# Altium schematic sheet tsal.SchDoc
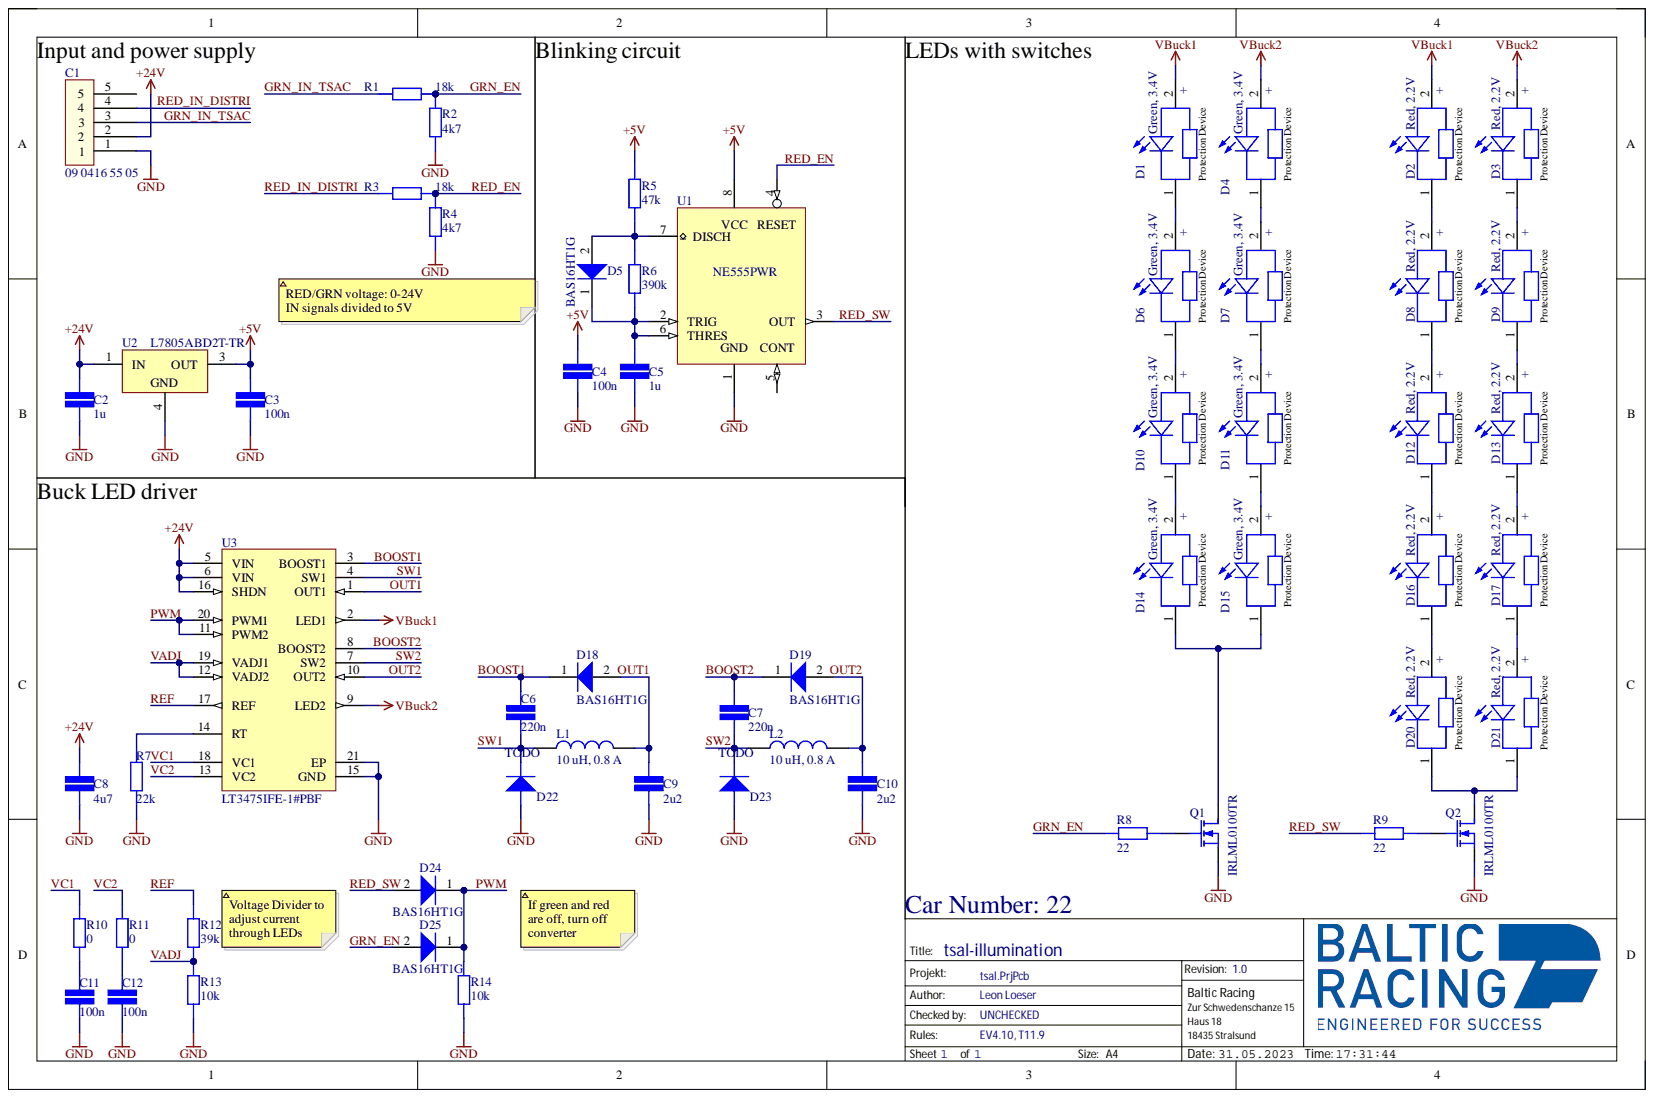
[diagram p0: tsal.SchDoc]

## Parts
C1  09 0416 55 05  [BINDER_09_0416_30_05]
C2  value 1u  [CAP 0805/2012]  (hidden comment: SMD Capacitor)
C3  value 100n  [CAP 0603/1608]  (hidden comment: SMD Capacitor)
C4  value 100n  [CAP 0603/1608]  (hidden comment: SMD Capacitor)
C5  value 1u  [CAP 0805/2012]  (hidden comment: SMD Capacitor)
C6  value 220n  [CAP 0603/1608]  (hidden comment: SMD Capacitor)
C7  value 220n  [CAP 0603/1608]  (hidden comment: SMD Capacitor)
C8  value 4u7  [CAP 1206/3216]  (hidden comment: SMD Capacitor)
C9  value 2u2  [CAP 1206/3216]  (hidden comment: SMD Capacitor)
C10  value 2u2  [CAP 1206/3216]  (hidden comment: SMD Capacitor)
C11  value 100n  [CAP 0603/1608]  (hidden comment: SMD Capacitor)
C12  value 100n  [CAP 0603/1608]  (hidden comment: SMD Capacitor)
D1  Green, 3.4V  Forward Voltage: 3.4V  [WL-SMDC_3535]
D2  Red, 2.2V  Forward Voltage: 2.2V  [WL-SMDC_3535]
D3  Red, 2.2V  Forward Voltage: 2.2V  [WL-SMDC_3535]
D4  Green, 3.4V  Forward Voltage: 3.4V  [WL-SMDC_3535]
D5  BAS16HT1G  [ONSC-SOD-323-2-477-02_V]
D6  Green, 3.4V  Forward Voltage: 3.4V  [WL-SMDC_3535]
D7  Green, 3.4V  Forward Voltage: 3.4V  [WL-SMDC_3535]
D8  Red, 2.2V  Forward Voltage: 2.2V  [WL-SMDC_3535]
D9  Red, 2.2V  Forward Voltage: 2.2V  [WL-SMDC_3535]
D10  Green, 3.4V  Forward Voltage: 3.4V  [WL-SMDC_3535]
D11  Green, 3.4V  Forward Voltage: 3.4V  [WL-SMDC_3535]
D12  Red, 2.2V  Forward Voltage: 2.2V  [WL-SMDC_3535]
D13  Red, 2.2V  Forward Voltage: 2.2V  [WL-SMDC_3535]
D14  Green, 3.4V  Forward Voltage: 3.4V  [WL-SMDC_3535]
D15  Green, 3.4V  Forward Voltage: 3.4V  [WL-SMDC_3535]
D16  Red, 2.2V  Forward Voltage: 2.2V  [WL-SMDC_3535]
D17  Red, 2.2V  Forward Voltage: 2.2V  [WL-SMDC_3535]
D18  BAS16HT1G  [ONSC-SOD-323-2-477-02_V]
D19  BAS16HT1G  [ONSC-SOD-323-2-477-02_V]
D20  Red, 2.2V  Forward Voltage: 2.2V  [WL-SMDC_3535]
D21  Red, 2.2V  Forward Voltage: 2.2V  [WL-SMDC_3535]
D22  TODO  [SOD123F]
D23  TODO  [SOD123F]
D24  BAS16HT1G  [ONSC-SOD-323-2-477-02_V]
D25  BAS16HT1G  [ONSC-SOD-323-2-477-02_V]
L1  10 uH, 0.8 A  Rated Current: 0.8 A  [WE-LQSH_2512]
L2  10 uH, 0.8 A  Rated Current: 0.8 A  [WE-LQSH_2512]
Q1  IRLML0100TR  [SOT23]
Q2  IRLML0100TR  [SOT23]
R1  value 18k  [STACKPOLE CSR0603]  (hidden comment: SMD Resistor)
R2  value 4k7  [STACKPOLE CSR0603]  (hidden comment: SMD Resistor)
R3  value 18k  [STACKPOLE CSR0603]  (hidden comment: SMD Resistor)
R4  value 4k7  [STACKPOLE CSR0603]  (hidden comment: SMD Resistor)
R5  value 47k  [STACKPOLE CSR0603]  (hidden comment: SMD Resistor)
R6  value 390k  [STACKPOLE CSR0603]  (hidden comment: SMD Resistor)
R7  value 22k  [STACKPOLE CSR0603]  (hidden comment: SMD Resistor)
R8  value 22  [STACKPOLE CSR0603]  (hidden comment: SMD Resistor)
R9  value 22  [STACKPOLE CSR0603]  (hidden comment: SMD Resistor)
R10  value 0  [STACKPOLE CSR0603]  (hidden comment: SMD Resistor)
R11  value 0  [STACKPOLE CSR0603]  (hidden comment: SMD Resistor)
R12  value 39k  [STACKPOLE CSR0603]  (hidden comment: SMD Resistor)
R13  value 10k  [STACKPOLE CSR0603]  (hidden comment: SMD Resistor)
R14  value 10k  [STACKPOLE CSR0603]  (hidden comment: SMD Resistor)
U1  NE555PWR  [8-TSSOP]
U2  L7805ABD2T-TR  [TO-263_M]
U3  LT3475IFE-1#PBF  [LT-FE-20-EPV-CB_L]

## Nets
+5V: C3.2 C4.2 R5.2 U1.8 U2.3
+24V: C1.2 C2.2 C8.2 U2.1 U3.5(VIN) U3.6(VIN) U3.16(SHDN)
GND: C1.1 C2.1 C3.1 C4.1 C5.2 C8.1 C9.1 C10.1 C11.1 C12.1 D22.A D23.A Q1.2 Q2.2 R2.1 R4.1 R7.2 R13.2 R14.2 U1.1 U2.4 U3.15(GND) U3.21(EP)
VBuck1: D1.2 D2.2 U3.2(LED1)
VBuck2: D3.2 D4.2 U3.9(LED2)
BOOST1: C6.2 D18.1 U3.3(BOOST1)
BOOST2: C7.2 D19.1 U3.8(BOOST2)
GRN_EN: D25.2 R1.2 R2.2 R8.2
GRN_IN_TSAC: C1.3 R1.1
OUT1: C9.2 D18.2 L1.2 U3.1(OUT1)
OUT2: C10.2 D19.2 L2.2 U3.10(OUT2)
PWM: D24.1 D25.1 R14.1 U3.11(PWM2) U3.20(PWM1)
RED_EN: R3.2 R4.2 U1.4
RED_IN_DISTRI: C1.4 R3.1
RED_SW: D24.2 R9.2 U1.3(OUT)
REF: R12.1 U3.17(REF)
SW1: C6.1 D22.C L1.1 U3.4(SW1)
SW2: C7.1 D23.C L2.1 U3.7(SW2)
VADJ: R12.2 R13.1 U3.12(VADJ2) U3.19(VADJ1)
VC1: R10.1 U3.18(VC1)
VC2: R11.1 U3.13(VC2)
NetC5_1: C5.1 D5.1 R6.1 U1.2(TRIG) U1.6(THRES)
NetC11_2: C11.2 R10.2
NetC12_2: C12.2 R11.2
NetD1_1: D1.1 D6.2
NetD2_1: D2.1 D8.2
NetD3_1: D3.1 D9.2
NetD4_1: D4.1 D7.2
NetD5_2: D5.2 R5.1 R6.2 U1.7(DISCH)
NetD6_1: D6.1 D10.2
NetD7_1: D7.1 D11.2
NetD8_1: D8.1 D12.2
NetD9_1: D9.1 D13.2
NetD10_1: D10.1 D14.2
NetD11_1: D11.1 D15.2
NetD12_1: D12.1 D16.2
NetD13_1: D13.1 D17.2
NetD14_1: D14.1 D15.1 Q1.3
NetD16_1: D16.1 D20.2
NetD17_1: D17.1 D21.2
NetD20_1: D20.1 D21.1 Q2.3
NetQ1_1: Q1.1 R8.1
NetQ2_1: Q2.1 R9.1
NetR7_1: R7.1 U3.14(RT)

Not connected: C1 5; U1 5

## Library data of the parts
C1 = 09 0416 55 05: Binder 5 Pin Female SnapIn 90°; Component Kind: Standard; Library Name: Leon.IntLib
C2 C6-C12 = SMD Capacitor: Capacitor with multiple Footprints; Voltage: 25V; Component Kind: Standard; Library Name: Standardbauteile.IntLib
C3-C5 = SMD Capacitor: Capacitor with multiple Footprints; Voltage: 6.3V; Component Kind: Standard; Library Name: Standardbauteile.IntLib
D1 D4 D6 D7 D10 D11 D14 D15 = 150353GS74500: WL-SMDC SMT Mono-color Ceramic LED Waterclear, size 3535, 3.4V, Green; Manufacturer: Wurth Elektronik; Chip Technology: InGaN; Peak Wavelength: 520nm; Viewing Angle: 125deg; Component Kind: Standard; ComponentLink1Description: Manufacturer; ComponentLink1URL: http://www.we-online.de; ComponentLink2Description: Datasheet; ComponentLink2URL: https://katalog.we-online.de/led/datasheet/150353GS74500.pdf; Emitting Color: Green; Library Name: Altium_WL-SMDC (rev20a).IntLib; Manufacturer Part Number: 150353GS74500; Mounting Technology: SMT; Operating Temperature: -40 to +125degC; Packaging: Tape and Reel; Published Date: October 2019; Size: 3535
D2 D3 D8 D9 D12 D13 D16 D17 D20 D21 = 150353RS74500: WL-SMDC SMT Mono-color Ceramic LED Waterclear, size 3535, 2.2V, Red; Manufacturer: Wurth Elektronik; Chip Technology: AlInGaP; Peak Wavelength: 635nm; Viewing Angle: 125deg; Component Kind: Standard; ComponentLink1Description: Manufacturer; ComponentLink1URL: http://www.we-online.de; ComponentLink2Description: Datasheet; ComponentLink2URL: https://katalog.we-online.de/led/datasheet/150353RS74500.pdf; Emitting Color: Red; Library Name: Altium_WL-SMDC (rev20a).IntLib; Manufacturer Part Number: 150353RS74500; Mounting Technology: SMT; Operating Temperature: -40 to +125degC; Packaging: Tape and Reel; Published Date: October 2019; Size: 3535
D5 D18 D19 D24 D25 = CMP-1054-00068-1: Switching Diode, 2-Pin SOD-323, Pb-Free, Tape and Reel; Manufacturer: On Semiconductor; Configuration: Single; IF Max (A): 0.2; trr Max (ns): 6; Component Kind: Standard; ComponentLink1Description: Manufacturer URL; ComponentLink1URL: http://www.onsemi.com/; ComponentLink2Description: Datasheet; ComponentLink2URL: http://www.onsemi.com/pub_link/Collateral/BAS16HT1-D.PDF; ComponentLink3Description: Package Specification; ComponentLink3URL: http://www.onsemi.com/pub_link/Collateral/477-02.PDF.1; DatasheetVersion: Rev. 11; Library Name: Altium Content Vault; Mounting Technology: Surface Mount; PackageDescription: 2-Pin SOD, Body 1.7 x 1.25 mm; PackageReference: SOD-323-2-477-02; PackageVersion: Rev. H, 03/2007; Packing: Tape and Reel; PartNumber: BAS16HT1G; RoHS: TRUE
D22 D23 = SMD Diode: Component Kind: Standard; Library Name: Standardbauteile.IntLib
L1 L2 = 74405024100: SMT Semi-Shielded High Saturation Power Inductor WE-LQSH, size 2512, 10 uH, 0.8 A; DC Resistance max.: 522 mOhm; Inductance: 10 uH; Peak Voltage max.: 25 V; Saturation Current: 1.2 A; Self Resonant Frequency: 20 MHz; Component Kind: Standard; ComponentLink1Description: Manufacturer; ComponentLink1URL: http://www.we-online.de; ComponentLink2Description: Datasheet; ComponentLink2URL: https://katalog.we-online.de/pbs/datasheet/74405024100.pdf; Library Name: ALTIUM-WE-LQSH-rev18a.IntLib; Mounting Technology: SMT; Operating Temperature: -40 to +125 degC; Packaging: Tape and Reel; Part Number: 74405024100; Published Date: November 2018; Size: 2512; Publisher: Wurth Elektronik
Q1 Q2 = MOSFET N: N-Channel MOSFET; Component Kind: Standard; Library Name: Standardbauteile.IntLib
R1-R14 = SMD Resistor: Resistor with multiple footprints; Component Kind: Standard; Library Name: Standardbauteile.IntLib
U1 = NE555: Component Kind: Standard; Library Name: Standardbauteile.IntLib
U2 = CMP-0244-00199-1: Positive Voltage Regulator, 3-Pin D2PAK, Tape and Reel; Component Kind: Standard; ComponentLink1Description: Manufacturer URL; ComponentLink1URL: http://www.st.com; ComponentLink2Description: Datasheet; ComponentLink2URL: http://www.st.com/internet/com/TECHNICAL_RESOURCES/TECHNICAL_LITERATURE/DATASHEET/CD00000444.pdf; DatasheetVersion: 16/11/2011; Library Name: Altium Content Vault; PackageDescription: 3-Pin Transistor Outline Package 2.54 mm Pitch, 15.4 mm Lead Span (D2PAK); PackageReference: TO-263; PackageVersion: 2011
U3 = CMP-0477-00152-1: Dual Step-Down 1.5 A LED Driver, 4 to 36 V Vin, 25 V Vout MAX, 20-pin SOP (FE-20-EPV-CB), -40 to 125 degC, Pb-Free; Manufacturer: Linear Technology; Topology: Buck, LED Driver; Vin Min(V): 4; Component Kind: Standard; ComponentLink1Description: Manufacturer URL; ComponentLink1URL: http://www.linear.com/; ComponentLink2Description: Datasheet; ComponentLink2URL: http://cds.linear.com/docs/en/datasheet/3475fb.pdf; ComponentLink3Description: Package Specification; ComponentLink3URL: http://cds.linear.com/docs/en/packaging/05081663__J_FE20(CB).pdf; DatasheetVersion: Rev. B; Library Name: Altium Content Vault; Mounting Technology: Surface Mount; PackageDescription: 20-Pin Plastic TSSOP, Body 6.5 x 4.4 mm, Pitch 0.65 mm; PackageReference: FE-20; PackageVersion: Rev. I, 05/2011; PartNumber: LT3475IFE-1#PBF; RoHS: TRUE
